# Altium schematic sheet SCHEMATIC_MAIN.SchDoc
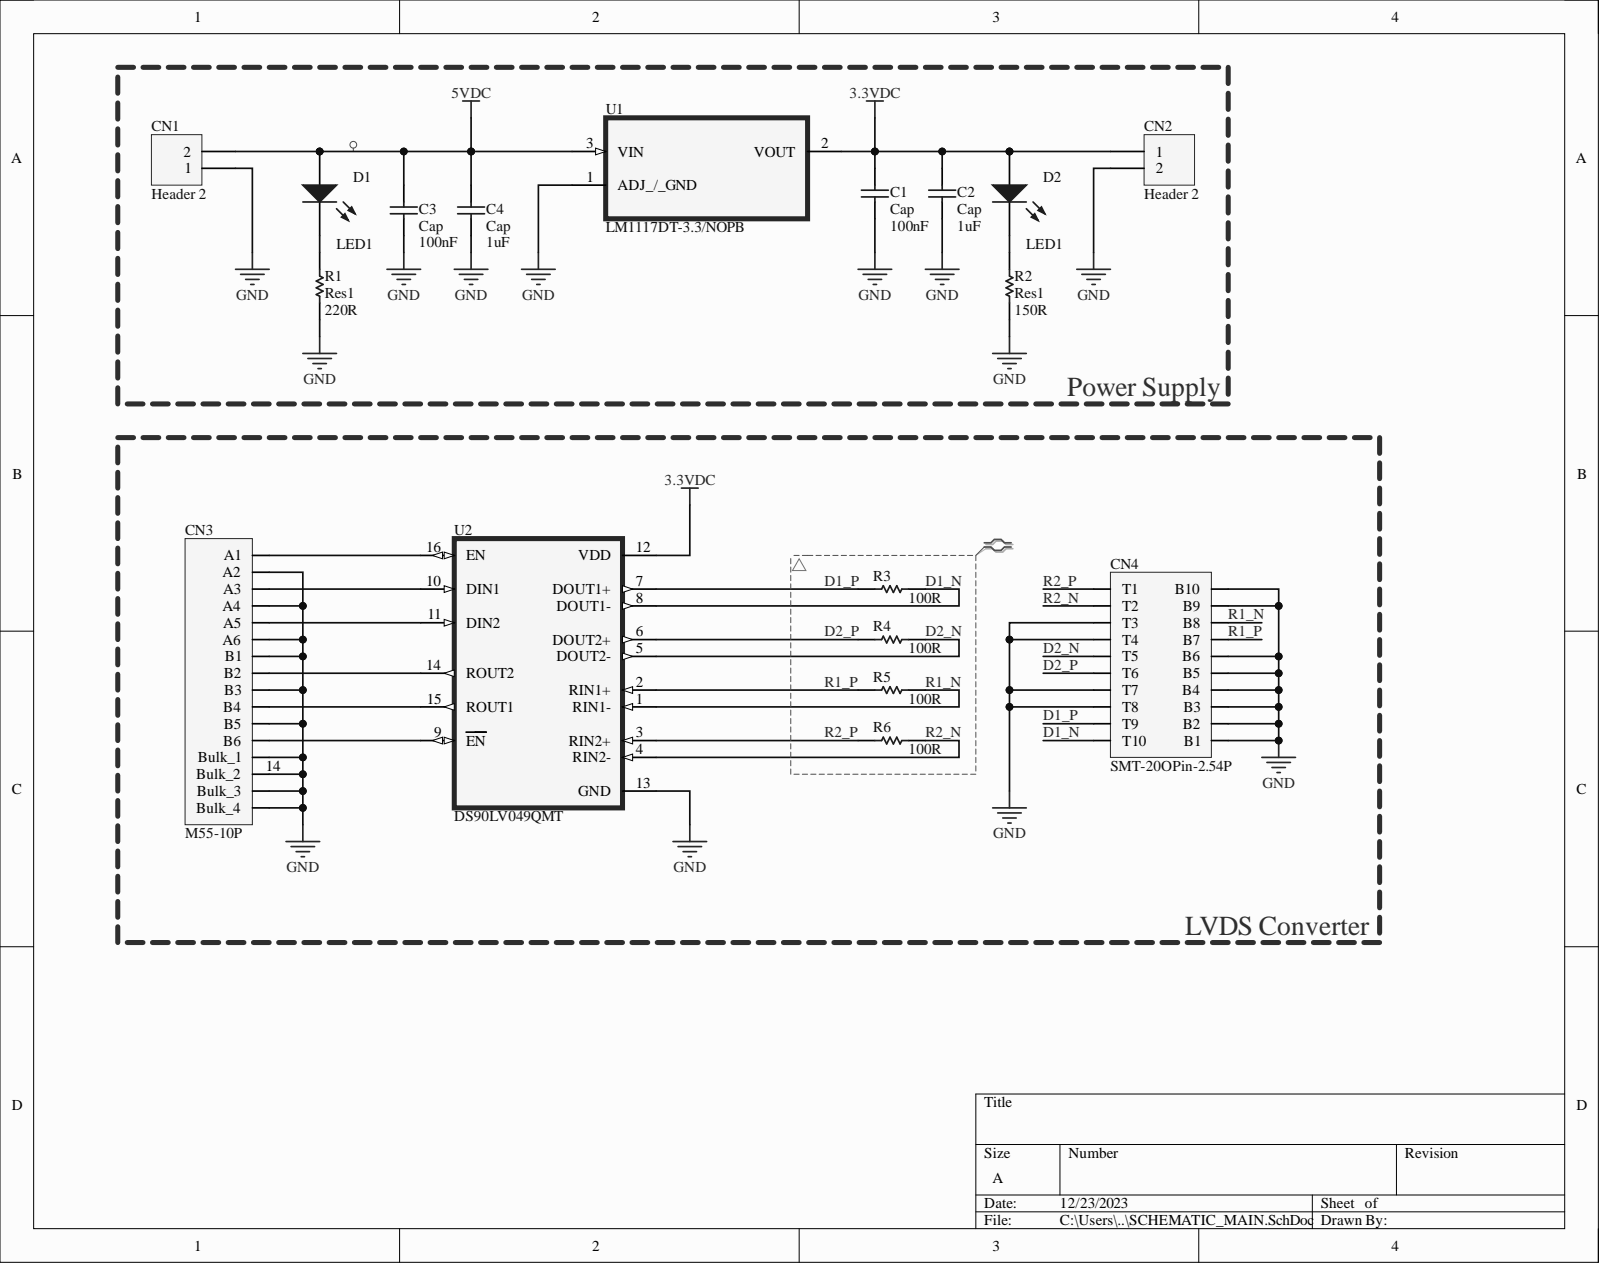
[diagram p0: SCHEMATIC_MAIN.SchDoc]

## Parts
C1  Cap  value 100nF  [6-0805_M]
C2  Cap  value 1uF  [6-0805_M]
C3  Cap  value 100nF  [6-0805_M]
C4  Cap  value 1uF  [6-0805_M]
CN1  Header 2  [HDR1X2]
CN2  Header 2  [HDR1X2]
CN3  M55-10P  [2TH-14SMT]
CN4  SMT-20OPin-2.54P  [SMT-20OPin-2.54P]
D1  LED1  [6-0805_M]
D2  LED1  [6-0805_M]
R1  Res1  value 220R  [6-0805_M]
R2  Res1  value 150R  [6-0805_M]
R3  value 100R  [6-0805_M]  (hidden comment: Res1)
R4  value 100R  [6-0805_M]  (hidden comment: Res1)
R5  value 100R  [6-0805_M]  (hidden comment: Res1)
R6  value 100R  [6-0805_M]  (hidden comment: Res1)
U1  LM1117DT-3.3/NOPB  [TO228P970X238-3N]
U2  DS90LV049QMT  [SOP65P640X120-16N]

## Nets
3.3VDC: C1.2 C2.2 CN2.1 D2.1 U1.2 U2.12(VDD)
5VDC: C3.2 C4.2 CN1.2 D1.1 U1.3
GND: C1.1 C2.1 C3.1 C4.1 CN1.1 CN2.2 CN3.2(A2) CN3.4(A4) CN3.6(A6) CN3.7(B1) CN3.9(B3) CN3.11(B5) CN3.13(Bulk_1) CN3.14(Bulk_2) CN3.15(Bulk_3) CN3.16(Bulk_4) CN4.3(T3) CN4.4(T4) CN4.7(T7) CN4.8(T8) CN4.11(B1) CN4.12(B2) CN4.13(B3) CN4.14(B4) CN4.15(B5) CN4.16(B6) CN4.19(B9) CN4.20(B10) R1.1 R2.1 U1.1 U2.13(GND)
D1_N: CN4.10(T10) R3.1 U2.8(DOUT1-)
D1_P: CN4.9(T9) R3.2 U2.7(DOUT1+)
D2_N: CN4.5(T5) R4.1 U2.5(DOUT2-)
D2_P: CN4.6(T6) R4.2 U2.6(DOUT2+)
R1_N: CN4.18(B8) R5.1 U2.1(RIN1-)
R1_P: CN4.17(B7) R5.2 U2.2(RIN1+)
R2_N: CN4.2(T2) R6.1 U2.4(RIN2-)
R2_P: CN4.1(T1) R6.2 U2.3(RIN2+)
NetCN3_1: CN3.1(A1) U2.16(EN)
NetCN3_3: CN3.3(B2) U2.14(ROUT2)
NetCN3_5: CN3.5(A3) U2.10(DIN1)
NetCN3_8: CN3.8(B4) U2.15(ROUT1)
NetCN3_10: CN3.10(A5) U2.11(DIN2)
NetCN3_12: CN3.12(B6) U2.9(EN)
NetD1_2: D1.2 R1.2
NetD2_2: D2.2 R2.2

## Library data of the parts
C1-C4 = Cap: Capacitor; Component Kind: Standard; LatestRevisionDate: 17-Jul-2002; LatestRevisionNote: Re-released for DXP Platform.; Library Name: Miscellaneous Devices.IntLib; PackageDescription: Radial Cap, Thru-Hole; 2 Leads; 0.3 in Pin Spacing; PackageReference: RAD-0.3; Published: 8-Jun-2000; Signal Integrity: Cap; Simulation: CAP; Publisher: Altium Limited
CN1 CN2 = Header 2: Header, 2-Pin; Component Kind: Standard; LatestRevisionDate: 17-Jul-2002; LatestRevisionNote: Re-released for DXP Platform.; Library Name: Miscellaneous Connectors.IntLib; Signal Integrity: Connector; Publisher: Altium Limited
CN3 = M55-7101286646: 10 Position SMD Connector; Component Kind: Standard; Library Name: M55-7101286646.IntLib
D1 D2 = LED1: Typical RED GaAs LED; Component Kind: Standard; LatestRevisionDate: 08-Jul-2005; LatestRevisionNote: Mech Layer 1 removed.; Library Name: Miscellaneous Devices.IntLib; PackageDescription: LED; 2 Leads; PackageReference: LED-1; Published: 8-Jun-2000; Simulation: LED1; Publisher: Altium Limited
R1-R6 = Res1: Resistor; Component Kind: Standard; LatestRevisionDate: 17-Jul-2002; LatestRevisionNote: Re-released for DXP Platform.; Library Name: Miscellaneous Devices.IntLib; PackageDescription: Axial Device, Thru-Hole; 2 Leads; 0.3 in Pin Spacing; PackageReference: AXIAL-0.3; Published: 8-Jun-2000; Signal Integrity: Res; Simulation: RESISTOR; Publisher: Altium Limited
U1 = LM1117DT-3.3/NOPB: Linear Voltage Regulator IC 1 Output 800mA TO-252-3; MF: Texas Instruments; MP: LM1117DT33; Availability: Unavailable; Component Kind: Standard; Library Name: LM1117DT-3.3_NOPB.IntLib; Package: None; Price: None; SnapEDA_Link: https://www.snapeda.com/parts/LM1117DT33/Texas%20Instruments/view-part/19360/?ref=snap
U2 = DS90LV049QMT: Automotive LVDS Dual High-Speed Differential Transceiver 16-TSSOP -40 to 125; MF: Texas Instruments; MP: DS90LV049QMT/NOPB; Availability: Warning; Component Kind: Standard; DigiKey-Purchase-URL: https://snapeda.com/shop?store=DigiKey&id=15994; Library Name: DS90LV049QMT.IntLib; Mouser-Purchase-URL: https://snapeda.com/shop?store=Mouser&id=15994; Package: TSSOP-16 Texas Instruments; Price: None; SnapEDA_Link: https://www.snapeda.com/parts/DS90LV049QMT/NOPB/Texas%20Instruments/view-part/15994/?ref=snap; Texas_Instruments-Purchase-URL: https://snapeda.com/shop?store=Texas+Instruments&id=15994
CN4 = SMT-20OPin-2.54P: Component Kind: Standard; Library Name: PCB Based SMT CNs.IntLib
